# Altium schematic sheet GPS.SchDoc(GPS)
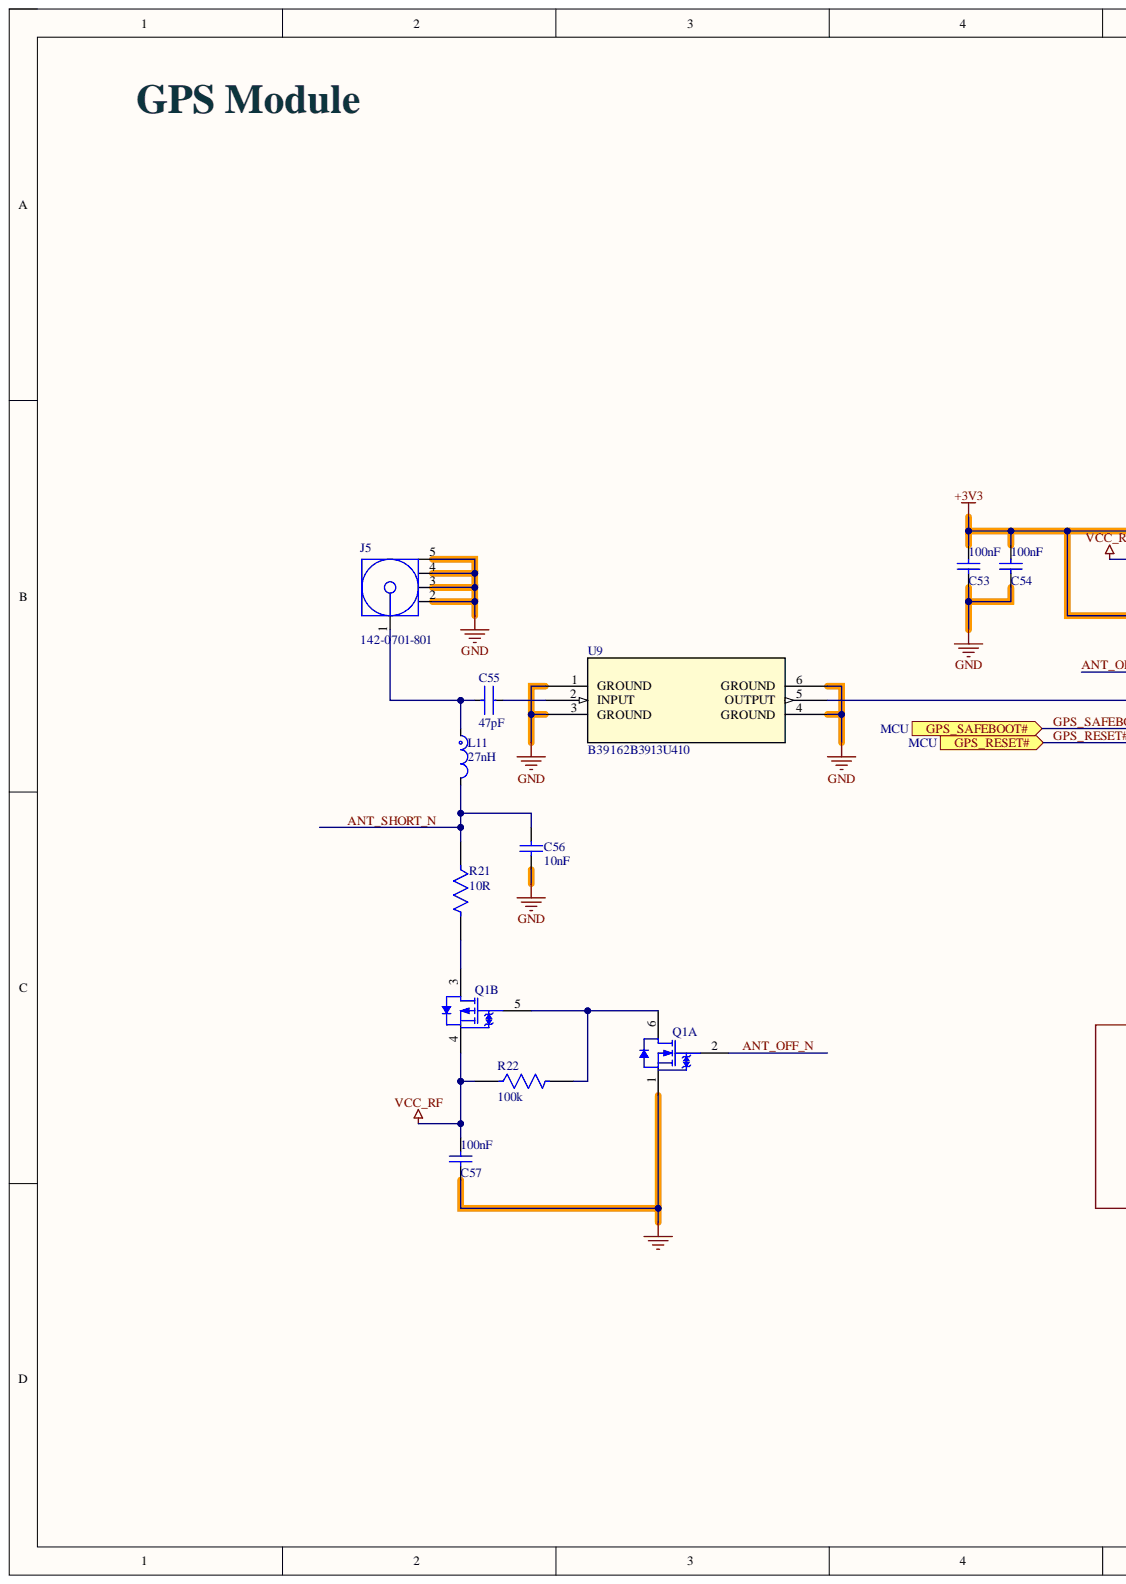
[diagram p0: GPS.SchDoc, left]
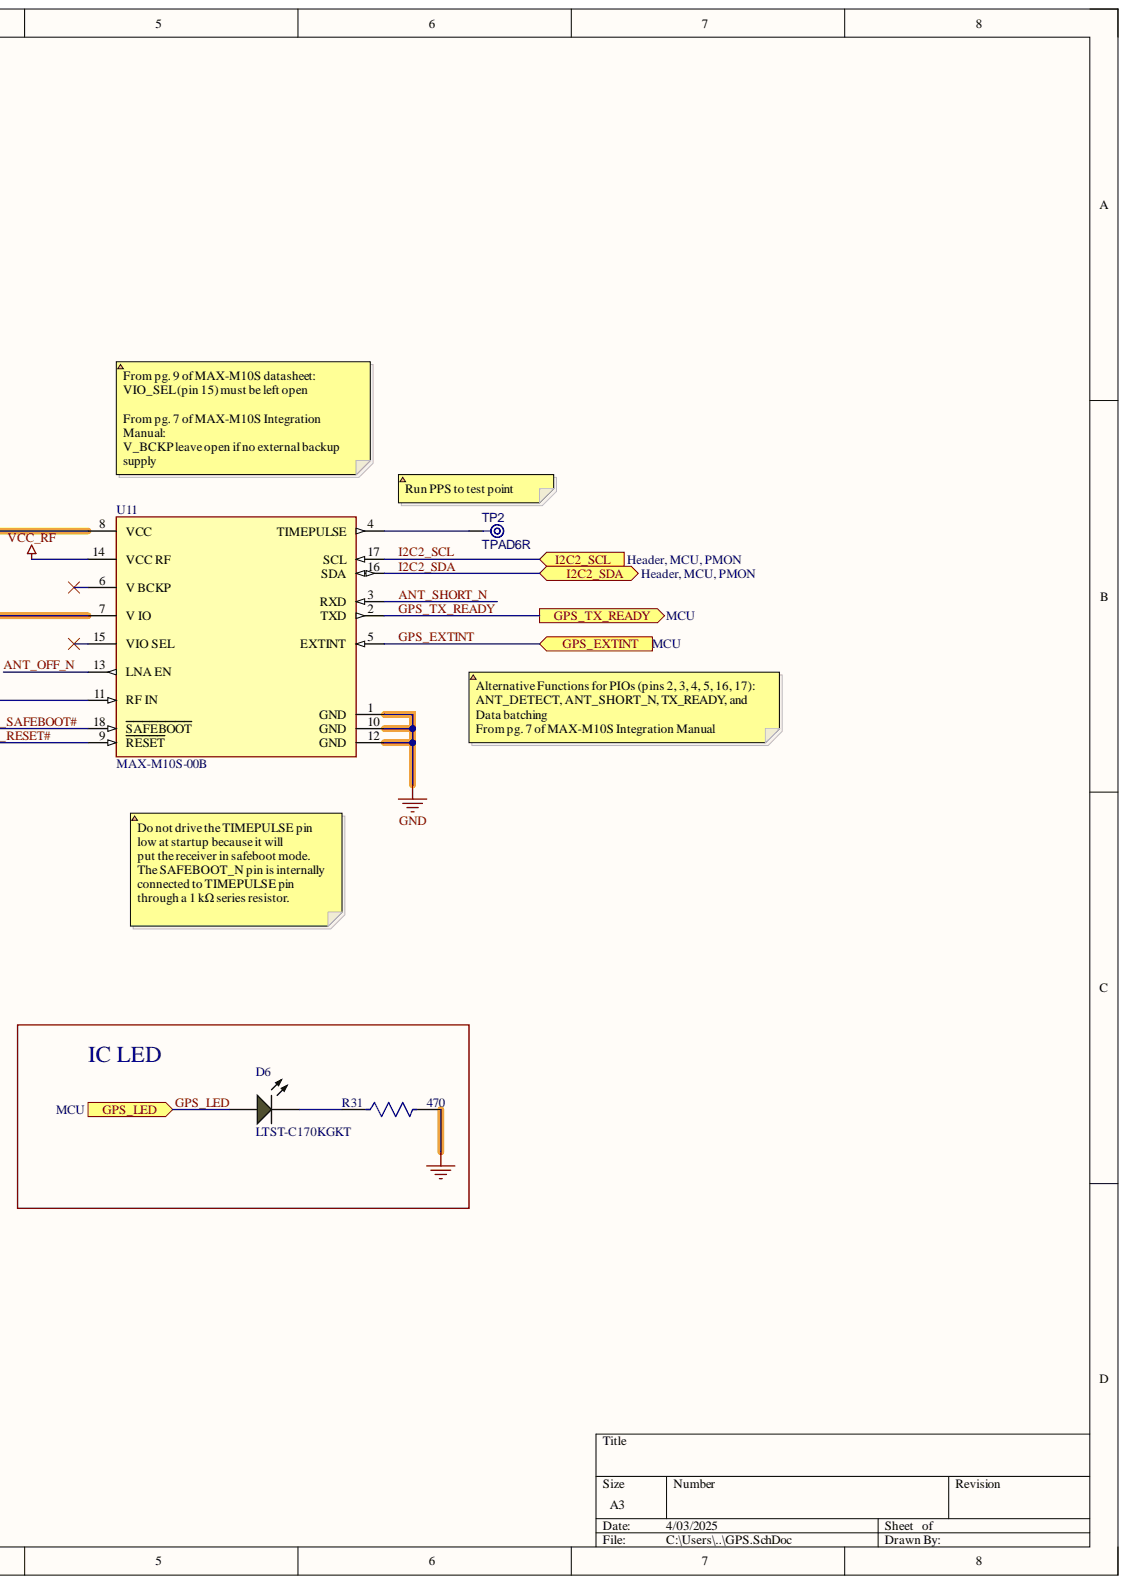
[diagram p1: GPS.SchDoc, right]

## Parts
C53  100nF  [CAPC1005X55X25ML05T10]
C54  100nF  [CAPC1005X55X25ML05T10]
C55  47pF  [FP-C0603C-CF-MFG]
C56  10nF  [CAPC1005X55X25LL05T10]
C57  100nF  [CAPC1005X55X25ML05T10]
D6  LTST-C170KGKT  [LTST-LTST-C170KGKT-2_V]
J5  142-0701-801  [CINC-142-0701-801_V]
L11  27nH  [FP-LQG15HH_02-IPC_C]
Q1  NTZD3155CT1G  [ONSC-SOT-563-6-463A-01_V]  (value not drawn)  (parts A,B)
R21  10R  [FP-CRCW1206-e3-IPC_B]
R22  100k  [FP-CRCW0603-e3-IPC_C]
R31  470  [FP-CPF0603-MFG]
TP2  TPAD6R  [TP06R]  (no BOM)
U9  B39162B3913U410  [B39162B3913U410_QUA]
U11  MAX-M10S-00B  [FP-MAX-M10S-00B-MFG]

## Ports
GPS_EXTINT, GPS_LED, GPS_RESET#, GPS_SAFEBOOT#, GPS_TX_READY, I2C2_SCL, I2C2_SDA

## Nets
+3V3: C53.1 C54.1 U11.7(V_IO) U11.8(VCC)
GND: C53.2 C54.2 C56.1 C57.2 J5.2 J5.3 J5.4 J5.5 Q1.1 R31.2 U9.1(GROUND) U9.3(GROUND) U9.4(GROUND) U9.6(GROUND) U11.1(GND) U11.10(GND) U11.12(GND)
VCC_RF: C57.1 Q1.4 R22.1 U11.14(VCC_RF)
ANT_OFF_N: Q1.2 U11.13(LNA_EN)
ANT_SHORT_N: C56.2 L11.2 R21.1 U11.3(RXD)
GPS_EXTINT: U11.5(EXTINT) ; port GPS_EXTINT
GPS_LED: D6.2 ; port GPS_LED
GPS_RESET#: U11.9(RESET) ; port GPS_RESET#
GPS_SAFEBOOT#: U11.18(SAFEBOOT) ; port GPS_SAFEBOOT#
GPS_TX_READY: U11.2(TXD) ; port GPS_TX_READY
I2C2_SCL: U11.17(SCL) ; port I2C2_SCL
I2C2_SDA: U11.16(SDA) ; port I2C2_SDA
NetC55_1: C55.1 J5.1 L11.1
NetC55_2: C55.2 U9.2(INPUT)
NetD6_1: D6.1 R31.1
NetQ1_3: Q1.3 R21.2
NetQ1_5: Q1.5 Q1.6 R22.2
NetTP2_1: TP2.1 U11.4(TIMEPULSE)
NetU9_5: U9.5(OUTPUT) U11.11(RF_IN)

Not connected: U11 6(V_BCKP) 15(VIO_SEL)

## Library data of the parts
C53 C54 C57 = CMP-2008-04536-1: Manufacturer: Murata; Part Number: GRM155R60J104KA01D; Component Kind: Standard; Library Name: Altium Content Vault
C55 = CMP-2006-03632-2: CAP CER 47PF 25V 5% NP0 0603; Manufacturer: KEMET; Manufacturer Part Number: C0603C470J3GACTU; Automotive Grade: Grade 1; Material: Ceramic; Temperature Characteristic: C0G; Temperature Coefficient: 30ppm/°C; Tolerance: 0.05; Automotive: Yes; Capacitance: 47 pF; Category: Cap; Component Kind: Standard; ComponentLink2Description: Datasheet; ComponentLink2URL: https://api.kemet.com/component-edge/download/datasheet/C0603C100J5GACAUTO.pdf; Device Class L1: Passive Components; Device Class L2: Capacitors; Device Class L3: Ceramic Capacitors; Digikey Description: CAP CER 47PF 25V 5% NP0 0603; Height: 0.87mm; Lead Free: Yes; Library Name: Altium Content Vault; Mouser Description: Multilayer Ceramic Capacitors MLCC - SMD/SMT 25volts 47pF C0G 5%; Octopart: https://octopart.com/c0603c470j3gactu-kemet-8375742; Package: C0603C-CF; RoHS: TRUE; Temperature: -55°C to +125°C; Temperature Range High: +125°C; Temperature Range Low: -55°C; Value: 47 pF; Voltage Rating: 25 V
C56 = CMP-2000-06332-1: Chip Capacitor, 10 nF, 16 V, 10%, -55 to 125 degC, 0402 (1005 Metric), RoHS, Tape and Reel; Manufacturer: Murata; Capacitance: 10000pF; Dielectric: X7R; Rated Voltage: 16V; Case Size/Dimension: 0402; Component Kind: Standard; Datasheet URL: http://psearch.en.murata.com/capacitor/product/GRM155R71C103KA01%23.pdf; Datasheet Version: 06/2016; Library Name: Altium Content Vault; Manufacturer URL: http://www.murata.com/; Mounting Technology: SurfaceMount; Package Description: 2-Pin Surface Mount Device, Body 1 x 0.5 mm; Package Reference: 0402; Tolerance (%): ±10%
D6 = CMP-2000-06620-1: Ultra Bright AlInGaP Chip LED, 75 mW, -55 to 85 degC, 2-Pin SMD (0805), RoHS, Tape and Reel; Manufacturer: Lite-On; Chip Material: AlGaInP; DELETED: Compliant; Dominant Wave Length: 571nm; Emitting Color: YellowGreen; Forward Current: 30mA; Forward Voltage: 2.4V; High Brightness: Yes; Lum Intensity: 35mcd; Number of  Elements: 1; Peak Wavelength: 574nm; Power Dissipation: 75W; Power Dissipation Max: 75mW; Product Depth (mm): 1.25mm; Product Height (mm): 1.1mm; Product Length (mm): 2mm; Rad Hardened: No; Reverse Voltage: 5V; Shape Type: Rectangular; Test Current (It): 20mA; Type: Uni-Color; Viewing Angle: 130deg; Component Kind: Standard; Datasheet URL: http://optoelectronics.liteon.com/upload/download/DS22-2000-073/S_110_LTST-C170KGKT.pdf; Datasheet Version: Rev. G, 06/2005; Forward Current Max: 30mA; Lens Dimensions: 1.4x1.25x0.6mm; Library Name: Altium Content Vault; Luminous Intensity: 35mcd; Main Category: ChipLED; Manufacturer URL: http://www.us.liteon.com/; Mounting: SurfaceMount; Mounting Technology: SurfaceMount; Operating Temp Range: -55Cto85C; Operating Temperature Classification: Industrial; Package Description: 2-Pin Surface Mounted Device, Body 2 x 1.25 mm; Package Reference: LTST-C170KGKT-2; Package Type: ChipLed; Packaging: TapeandReel; Pin Count: 2
J5 = CMP-2000-05490-1: SMA End Launch Jack Receptacle, 50 Ohm, 0 to 18 GHz, -65 to 165 degC, 5-Pin SMD, RoHS, Bulk; Manufacturer: EmersonConnectivitySolutions; Body Material: Brass; Body Style: Straight; Brand: Johnson/EmersonConnectivitySolutions; Connector Type: JackPCB; Gender: Jack(Female); Impedance: 50Ohms; Maximum Frequency: 18GHz; Component Kind: Standard; Contact Plating: Gold; Datasheet URL: https://belfuse.com/resources/Johnson/productinformation/pi-142-0701-801.pdf; Datasheet Version: 12/2014; Factory Pack Quantity: 5; Library Name: Altium Content Vault; Manufacturer URL: http://www.cinch.com/; Mounting Technology: SurfaceMount; Operating Temperature Range: -65Cto+165C; Orientation: Straight; Package Description: 5-Pin Surface Mount Device, Body 14.275 x 9.525 mm; Package Reference: 142-0701-801; Package URL: https://belfuse.com/resources/Johnson/drawings/dr-1420701801.pdf; Product: Connectors; Product Category: RFConnectors/CoaxialConnectors; RF Series: SMA; RoHS: Details; Series: SMA; Termination Style: Solder
L11 = CMP-2000-06578-2: Multilayer type RF Inductor 27nH ±5% 0.46Ω 350mA 0402; Core Material: Air; Current Rating: 300mA; DC Resistance (DCR): 460mR; Q Factor: 8; Self Resonant Frequency: 1.7GHz; Shielding: Unshielded; Test Frequency: 100MHz; Case Code (Imperial): 0402; Case Code (Metric): 1005; Case/Package: 0402; Component Kind: Standard; DC Current: 300mA; Depth: 0.55mm; Height: 0.5mm; Height - Seated (Max): 0.022inch; Inductance: 27nH; Inductance Tolerance: 5%; Lead Free: Lead Free; Length: 1mm; Library Name: Altium Content Vault; Max DC Current: 300mA; Max Operating Temperature: 125°C; Military Standard: Not; Min Operating Temperature: -55°C; Mount: Surface Mount; Package Quantity: 10000; Packaging: Tape and Reel; REACH SVHC: No SVHC; Termination: SMD/SMT; Tolerance: 5%; Width: 0.02inch
Q1 = CMP-1058-00825-1: Small Signal MOSFET, Complementary 20 V, 540 mA / -430 mA, with ESD Protection, 6-Pin SOT-563, Pb-Free, Tape and Reel; Manufacturer: On Semiconductor; Configuration: Dual; Crss Typ (pF): 10; V(BR)DSS Min (V): 20; VGS Max (V): 6; VGS(th) Max (V): 1; Channel Polarity: Complementary; Component Kind: Standard; ComponentLink1Description: Manufacturer URL; ComponentLink1URL: http://www.onsemi.com/; ComponentLink2Description: Datasheet; ComponentLink2URL: http://www.onsemi.com/pub_link/Collateral/NTZD3155C-D.PDF; ComponentLink3Description: Package Specification; ComponentLink3URL: http://www.onsemi.cn/pub_link/Collateral/463A-01.PDF; DatasheetVersion: Rev. 3; Library Name: Altium Content Vault; Mounting Technology: Surface Mount; Package Type: SOT-563; PackageDescription: 6-Pin Small Outline Transistor, Body 1.6 x 1.2 mm, Pitch 0.5 mm; PackageReference: SOT-563-6-463A-01; PackageVersion: Rev. F, 04/2005; Packing: Tape and Reel; PartNumber: NTZD3155CT1G; RoHS: Pb-Free
R21 = CMP-1014-00026-3: RES Thick Film, 10Ω, 5%, 0.25W, 200ppm/°C, 1206; Power Rating: 250mW; Voltage Rating: 200V; Case Code (Imperial): 1206; Case Code (Metric): 3216; Case/Package: 1206; Component Kind: Standard; Composition: Thick Film; Contact Plating: Tin; Depth: 1.6mm; Height: 0.55mm; Lead Free: Lead Free; Length: 3.2mm; Library Name: Altium Content Vault; Max Operating Temperature: 155°C; Military Standard: Not; Min Operating Temperature: -55°C; Number of Pins: 2; Package Quantity: 5000; Packaging: Tape and Reel; Radiation Hardening: No; Resistance: 10R; Temperature Coefficient: 200ppm/°C; Termination: SMD/SMT; Tolerance: 5%; Voltage Rating (DC): 200V; Weight: 0.000353oz; Width: 1.6mm
R22 = CMP-2000-03823-2: RES Thick Film, 100kΩ, 5%, 0.1W, 200ppm/°C, 0603; Max Power Dissipation: 100mW; Resistance: 100kR; Voltage Rating: 75V; Case Code (Imperial): 0603; Case Code (Metric): 1608; Case/Package: 0603; Component Kind: Standard; Composition: Thick Film; Contact Plating: Tin; Depth: 0.85mm; Features: Automotive AEC-Q200; Height: 0.02inch; Length: 1.55mm; Library Name: Altium Content Vault; Max Operating Temperature: 155°C; Military Standard: Not; Min Operating Temperature: -55°C; Mount: Surface Mount; Number of Pins: 2; Number of Terminations: 2; Package Quantity: 1; Packaging: Tape and Reel; Power Rating: 100mW; Radiation Hardening: No; Temperature Coefficient: 200ppm/°C; Termination: SMD/SMT; Tolerance: 5%; Weight: 7.1E-05oz; Width: 0.85mm; Working Voltage: 75V
R31 = CMP-03211-004765-1: RES SMD 470Ω 0.1% 1/16W 0603    CPF0603B470RE; Manufacturer: TE Connectivity; Power Rating: 0.063W; Temperature Coefficient: 25ppm/°C; Voltage Rating: 50V; Automotive: No; Category: Res; Component Kind: Standard; ComponentLink2Description: Datasheet; ComponentLink2URL: https://www.te.com/commerce/DocumentDelivery/DDEController?Action=showdoc&DocId=Data+Sheet%7F1773200%7FM%7Fpdf%7FEnglish%7FENG_DS_1773200_M.pdf%7F3-1676481-2; Composition: Thin Film; Device Class L1: Passive Components; Device Class L2: Resistors; Device Class L3: Chip SMD Resistors; Height: 0.55mm; Ipc Land Pattern Name: RESC15580X45; Lead Free: Yes; Library Name: Altium Content Vault; Manufacturer Part Number: CPF0603B470RE; Octopart: https://octopart.com/cpf0603b470re-te+connectivity-23376607; octopart_url: https://octopart.com/cpf0603b470re-te+connectivity-23376607; Package: CPF0603; packaging: 5k Reel; Resistance: 470Ω; RoHS: TRUE; Series: CPF; Temperature: -55°C to +155°C; Temperature Range High: +155°C; Temperature Range Low: -55°C; Tolerance: 0.1%; Value: 470Ω
TP2 = CMP-00028-00002-2: 0.6mm round; Pins: 1; Library Name: Personal Repository
U9 = CMP-026-000001-1: No Description Available; Mfr_Name: Qualcomm; Component Kind: Standard; ComponentLink1Description: New link; Design Item ID: B39162B3913U410; RefDes: RefDes; Source: B39162B3913U410.SchLib; Type: Type; Library Name: Personal Repository
U11 = CMP-207130-000026-1: GNSS LCC RX, ROM, TCXO, LNA, SAW; Component Kind: Standard; Library Name: Altium Content Vault
